# Altium schematic sheet Sheet2.SchDoc(Sheet2)
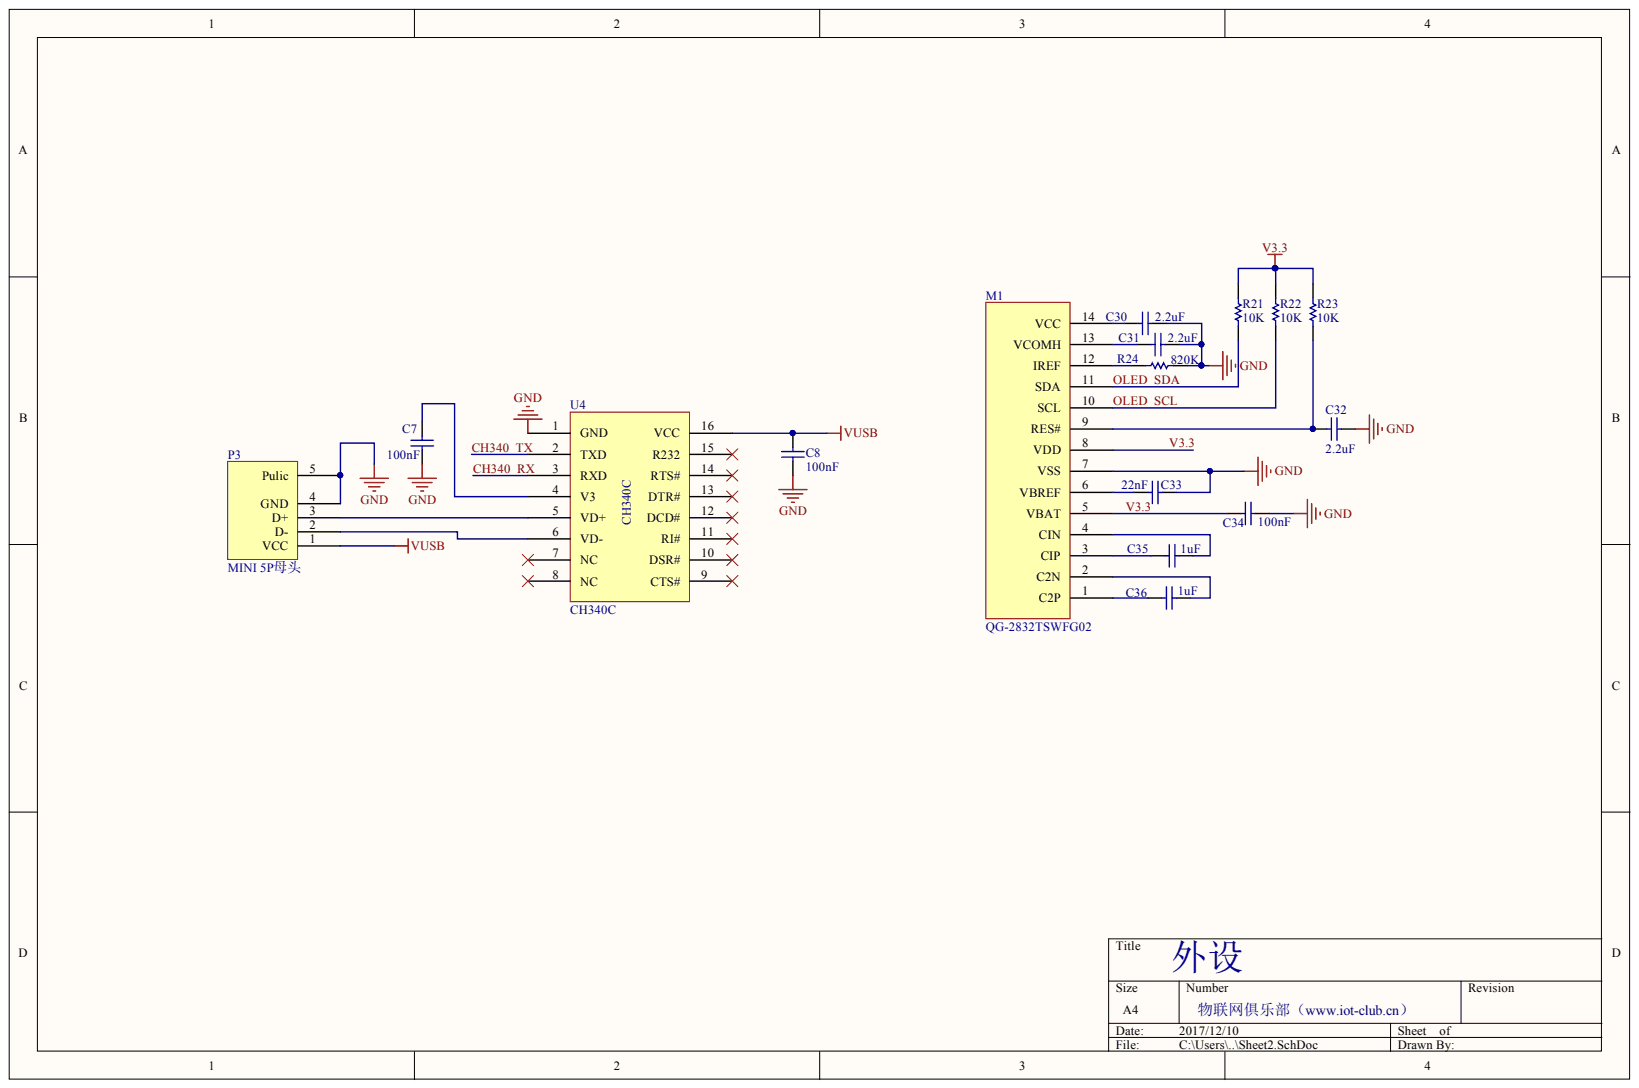
[diagram p0: Sheet2.SchDoc]

## Parts
C7  100nF  [C0603]
C8  100nF  [C0603]
C30  2.2uF  [C0603]
C31  2.2uF  [C0603]
C32  2.2uF  [C0603]
C33  22nF  [C0603]
C34  100nF  [C0603]
C35  1uF  [C0603]
C36  1uF  [C0603]
M1  QG-2832TSWFG02  [QG-2832TSWFG02]  (no BOM)
P3  MINI 5P母头  [USBMINI]  (no BOM)
R21  10K  [R0603]
R22  10K  [R0603]
R23  10K  [R0603]
R24  820K  [R0603]
U4  CH340C  [SOP16_N]

## Nets
GND: C7.1 C8.1 C30.2 C31.2 C32.2 C33.2 C34.1 M1.7(VSS) P3.4(GND) P3.5(Pulic) R24.2 U4.1(GND)
VUSB: C8.2 P3.1(VCC) U4.16(VCC)
CH340_RX: U4.3(RXD)
CH340_TX: U4.2(TXD)
OLED_SCL: M1.10(SCL) R22.1
OLED_SDA: M1.11(SDA) R21.1
V3.3: C34.2 M1.5(VBAT) M1.8(VDD) R21.2 R22.2 R23.2
NetC7_2: C7.2 U4.4(V3)
NetC30_1: C30.1 M1.14(VCC)
NetC31_1: C31.1 M1.13(VCOMH)
NetC32_1: C32.1 M1.9(RES#) R23.1
NetC33_1: C33.1 M1.6(VBREF)
NetC35_1: C35.1 M1.4(CIN)
NetC35_2: C35.2 M1.3(CIP)
NetC36_1: C36.1 M1.2(C2N)
NetC36_2: C36.2 M1.1(C2P)
NetM1_12: M1.12(IREF) R24.1
NetP3_2: P3.2(D-) U4.6(VD-)
NetP3_3: P3.3(D+) U4.5(VD+)

Not connected: U4 7(NC) 8(NC) 9(CTS#) 10(DSR#) 11(RI#) 12(DCD#) 13(DTR#) 14(RTS#) 15(R232)

## Library data of the parts
C7 C8 C30-C36 = CAP: Capacitor; Library Name: Devices.IntLib; Component Kind: Standard
M1 = OLED_0.91: Library Name: Custom.IntLib
P3 = USB_MINI: Library Name: Custom.IntLib
R21-R24 = Res1: Resistor; Value: 1K; Simulation: RESISTOR; Signal Integrity: Res; Published: 8-Jun-2000; PackageReference: AXIAL-0.3; PackageDescription: Axial Device, Thru-Hole; 2 Leads; 0.3 in Pin Spacing; Library Name: Devices.IntLib; LatestRevisionNote: Re-released for DXP Platform.; LatestRevisionDate: 17-Jul-2002; Component Kind: Standard; Publisher: Altium Limited
U4 = CH340C: Library Name: IC.SchLib; Component Kind: Standard
